# Altium schematic sheet Current_Sense.SchDoc
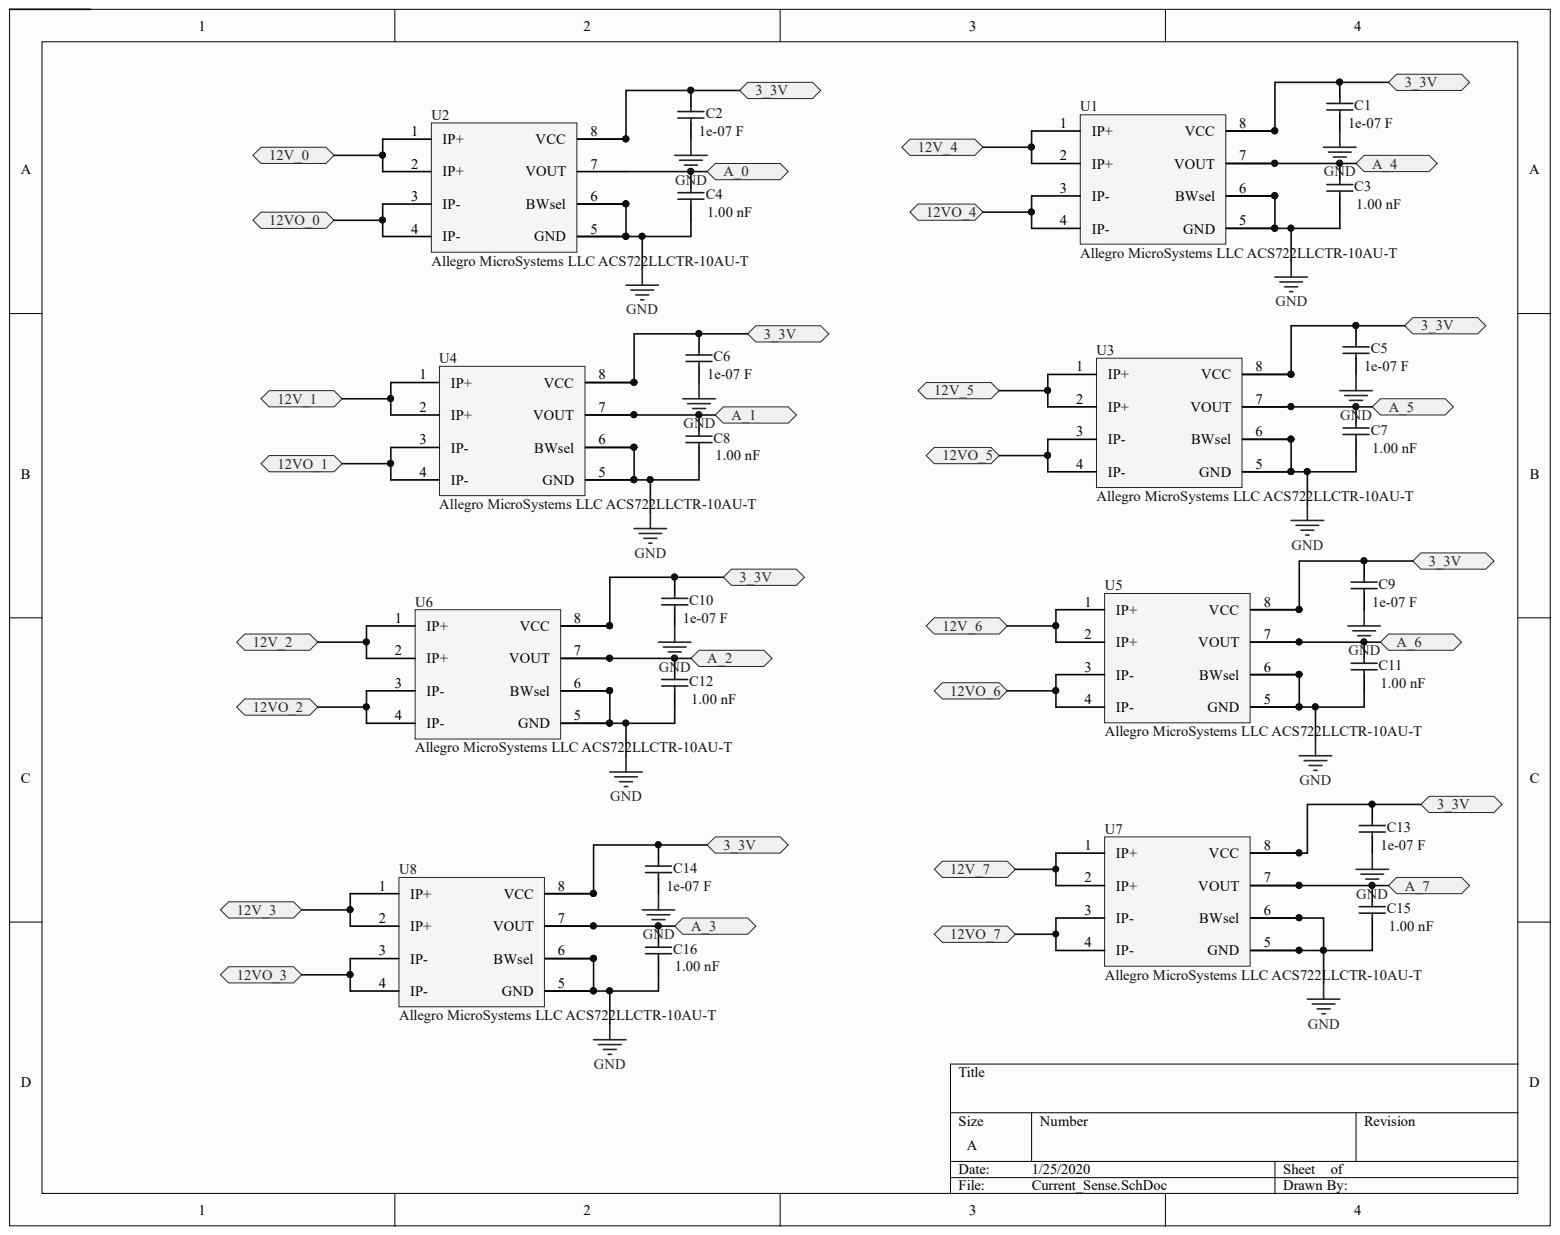
[diagram p0: Current_Sense.SchDoc]

## Parts
C1  Kemet C0603C104M4RACTU  [CAPC1608X87X35NL15T15]  (value not drawn)
C2  Kemet C0603C104M4RACTU  [CAPC1608X87X35NL15T15]  (value not drawn)
C3  Yageo CC0603KRX7R9BB102  [0603C_B]  (value not drawn)
C4  Yageo CC0603KRX7R9BB102  [0603C_B]  (value not drawn)
C5  Kemet C0603C104M4RACTU  [CAPC1608X87X35NL15T15]  (value not drawn)
C6  Kemet C0603C104M4RACTU  [CAPC1608X87X35NL15T15]  (value not drawn)
C7  Yageo CC0603KRX7R9BB102  [0603C_B]  (value not drawn)
C8  Yageo CC0603KRX7R9BB102  [0603C_B]  (value not drawn)
C9  Kemet C0603C104M4RACTU  [CAPC1608X87X35NL15T15]  (value not drawn)
C10  Kemet C0603C104M4RACTU  [CAPC1608X87X35NL15T15]  (value not drawn)
C11  Yageo CC0603KRX7R9BB102  [0603C_B]  (value not drawn)
C12  Yageo CC0603KRX7R9BB102  [0603C_B]  (value not drawn)
C13  Kemet C0603C104M4RACTU  [CAPC1608X87X35NL15T15]  (value not drawn)
C14  Kemet C0603C104M4RACTU  [CAPC1608X87X35NL15T15]  (value not drawn)
C15  Yageo CC0603KRX7R9BB102  [0603C_B]  (value not drawn)
C16  Yageo CC0603KRX7R9BB102  [0603C_B]  (value not drawn)
U1  Allegro MicroSystems LLC ACS722LLCTR-10AU-T  Manufacturer Part Number: ACS722LLCTR-10AU-T  [PCB-l9fj37zjcm6eghflru7o-1]
U2  Allegro MicroSystems LLC ACS722LLCTR-10AU-T  Manufacturer Part Number: ACS722LLCTR-10AU-T  [PCB-l9fj37zjcm6eghflru7o-1]
U3  Allegro MicroSystems LLC ACS722LLCTR-10AU-T  Manufacturer Part Number: ACS722LLCTR-10AU-T  [PCB-l9fj37zjcm6eghflru7o-1]
U4  Allegro MicroSystems LLC ACS722LLCTR-10AU-T  Manufacturer Part Number: ACS722LLCTR-10AU-T  [PCB-l9fj37zjcm6eghflru7o-1]
U5  Allegro MicroSystems LLC ACS722LLCTR-10AU-T  Manufacturer Part Number: ACS722LLCTR-10AU-T  [PCB-l9fj37zjcm6eghflru7o-1]
U6  Allegro MicroSystems LLC ACS722LLCTR-10AU-T  Manufacturer Part Number: ACS722LLCTR-10AU-T  [PCB-l9fj37zjcm6eghflru7o-1]
U7  Allegro MicroSystems LLC ACS722LLCTR-10AU-T  Manufacturer Part Number: ACS722LLCTR-10AU-T  [PCB-l9fj37zjcm6eghflru7o-1]
U8  Allegro MicroSystems LLC ACS722LLCTR-10AU-T  Manufacturer Part Number: ACS722LLCTR-10AU-T  [PCB-l9fj37zjcm6eghflru7o-1]

## Ports
3_3V, 12V_0, 12V_1, 12V_2, 12V_3, 12V_4, 12V_5, 12V_6, 12V_7, 12VO_0, 12VO_1, 12VO_2, 12VO_3, 12VO_4, 12VO_5, 12VO_6, 12VO_7, A_0, A_1, A_2, A_3, A_4, A_5, A_6, A_7

## Nets
GND: C1.1 C2.1 C3.1 C4.1 C5.1 C6.1 C7.1 C8.1 C9.1 C10.1 C11.1 C12.1 C13.1 C14.1 C15.1 C16.1 U1.5(GND) U1.6(BWsel) U2.5(GND) U2.6(BWsel) U3.5(GND) U3.6(BWsel) U4.5(GND) U4.6(BWsel) U5.5(GND) U5.6(BWsel) U6.5(GND) U6.6(BWsel) U7.5(GND) U7.6(BWsel) U8.5(GND) U8.6(BWsel)
3_3V: C1.2 C2.2 C5.2 C6.2 C9.2 C10.2 C13.2 C14.2 U1.8(VCC) U2.8(VCC) U3.8(VCC) U4.8(VCC) U5.8(VCC) U6.8(VCC) U7.8(VCC) U8.8(VCC) ; port 3_3V
12V_0: U2.1(IP+) U2.2(IP+) ; port 12V_0
12V_1: U4.1(IP+) U4.2(IP+) ; port 12V_1
12V_2: U6.1(IP+) U6.2(IP+) ; port 12V_2
12V_3: U8.1(IP+) U8.2(IP+) ; port 12V_3
12V_4: U1.1(IP+) U1.2(IP+) ; port 12V_4
12V_5: U3.1(IP+) U3.2(IP+) ; port 12V_5
12V_6: U5.1(IP+) U5.2(IP+) ; port 12V_6
12V_7: U7.1(IP+) U7.2(IP+) ; port 12V_7
12VO_0: U2.3(IP-) U2.4(IP-) ; port 12VO_0
12VO_1: U4.3(IP-) U4.4(IP-) ; port 12VO_1
12VO_2: U6.3(IP-) U6.4(IP-) ; port 12VO_2
12VO_3: U8.3(IP-) U8.4(IP-) ; port 12VO_3
12VO_4: U1.3(IP-) U1.4(IP-) ; port 12VO_4
12VO_5: U3.3(IP-) U3.4(IP-) ; port 12VO_5
12VO_6: U5.3(IP-) U5.4(IP-) ; port 12VO_6
12VO_7: U7.3(IP-) U7.4(IP-) ; port 12VO_7
A_0: C4.2 U2.7(VOUT) ; port A_0
A_1: C8.2 U4.7(VOUT) ; port A_1
A_2: C12.2 U6.7(VOUT) ; port A_2
A_3: C16.2 U8.7(VOUT) ; port A_3
A_4: C3.2 U1.7(VOUT) ; port A_4
A_5: C7.2 U3.7(VOUT) ; port A_5
A_6: C11.2 U5.7(VOUT) ; port A_6
A_7: C15.2 U7.7(VOUT) ; port A_7
N00328:

## Library data of the parts
C1 C2 C5 C6 C9 C10 C13 C14 = CMP-123633-3: CAP CER 0.1UF 16V 20% X7R 0603; Capacitance: 1e-07 F; Dielectric Material: Ceramic; Lead-Free Status: R; Voltage Rating (DC): 16.0 V; Capacitance Tolerance: ±20%; Case/Package: 0603; Component Kind: Standard; Dielectric Characteristic: X7R; Library Name: CommunityVault; Manufacturer Part Number: C0603C104M4RACTU; Mounting Style: Surface Mount; Number of Pins: 2; OctopartID: ce04070ce7e111c3; Octopart Url: https://octopart.com/c0603c104m4ractu-kemet-134275; Packaging: Cut Tape (CT); RoHS: Compliant; Size-Height: 0.0008 m; Size-Length: 0.0016 m; Size-Width: 0.0008 m
C3 C4 C7 C8 C11 C12 C15 C16 = CMP-bbc372cb6f006404-3: 0603 1 nF 50 V ±10 % Tolerance X7R SMT Multilayer Ceramic Capacitor; Capacitance: 1.00 nF; Capacitance Tolerance: ±10%; Insulation Resistance: 100 GΩ; Lead-Free Status: Lead Free; Voltage Rating (DC): 50.0 V; Case/Package: 0603; Component Kind: Standard; Dielectric Characteristic: X7R; Halogen Free Status: Halogen Free; Library Name: CommunityVault; Manufacturer Part Number: CC0603KRX7R9BB102; Mounting Style: Surface Mount; Number of Pins: 2; OctopartID: bbc372cb6f006404; Octopart Url: http://octopart.com/cc0603krx7r9bb102-yageo-192933; Packaging: Cut Tape (CT), Tape & Reel (TR); REACH SVHC Compliance: No SVHC; RoHS: Compliant; Seller Landing  Url: https://octopart-clicks.com/click/track?country=US&ak=8157f166&sig=010f1ca&sid=1106&ppid=192933&vpid=198824049&ct=offers; Size-Height: 810 µm; Size-Length: 1.60 mm; Size-Width: 810 µm
U1-U8 = CMP-af096451db3d70ce-5: High Accuracy, Galvanically Isolated Current Sensor IC With Small Footprint SOIC8 Package; Bandwidth: 80.0 kHz; Quiescent Current: 9.00 mA; Supply Voltage (DC): 3.00 V (min); Component Kind: Standard; Library Name: CommunityVault; Mounting Style: Surface Mount; Number of Pins: 8; OctopartID: af096451db3d70ce; Octopart URL: http://octopart.com/acs722llctr-10au-t-allegro+microsystems+llc-38949202; Packaging: Cut Tape (CT); RoHS: Compliant
